# Altium schematic sheet 12V-5V(3.3V).SchDoc
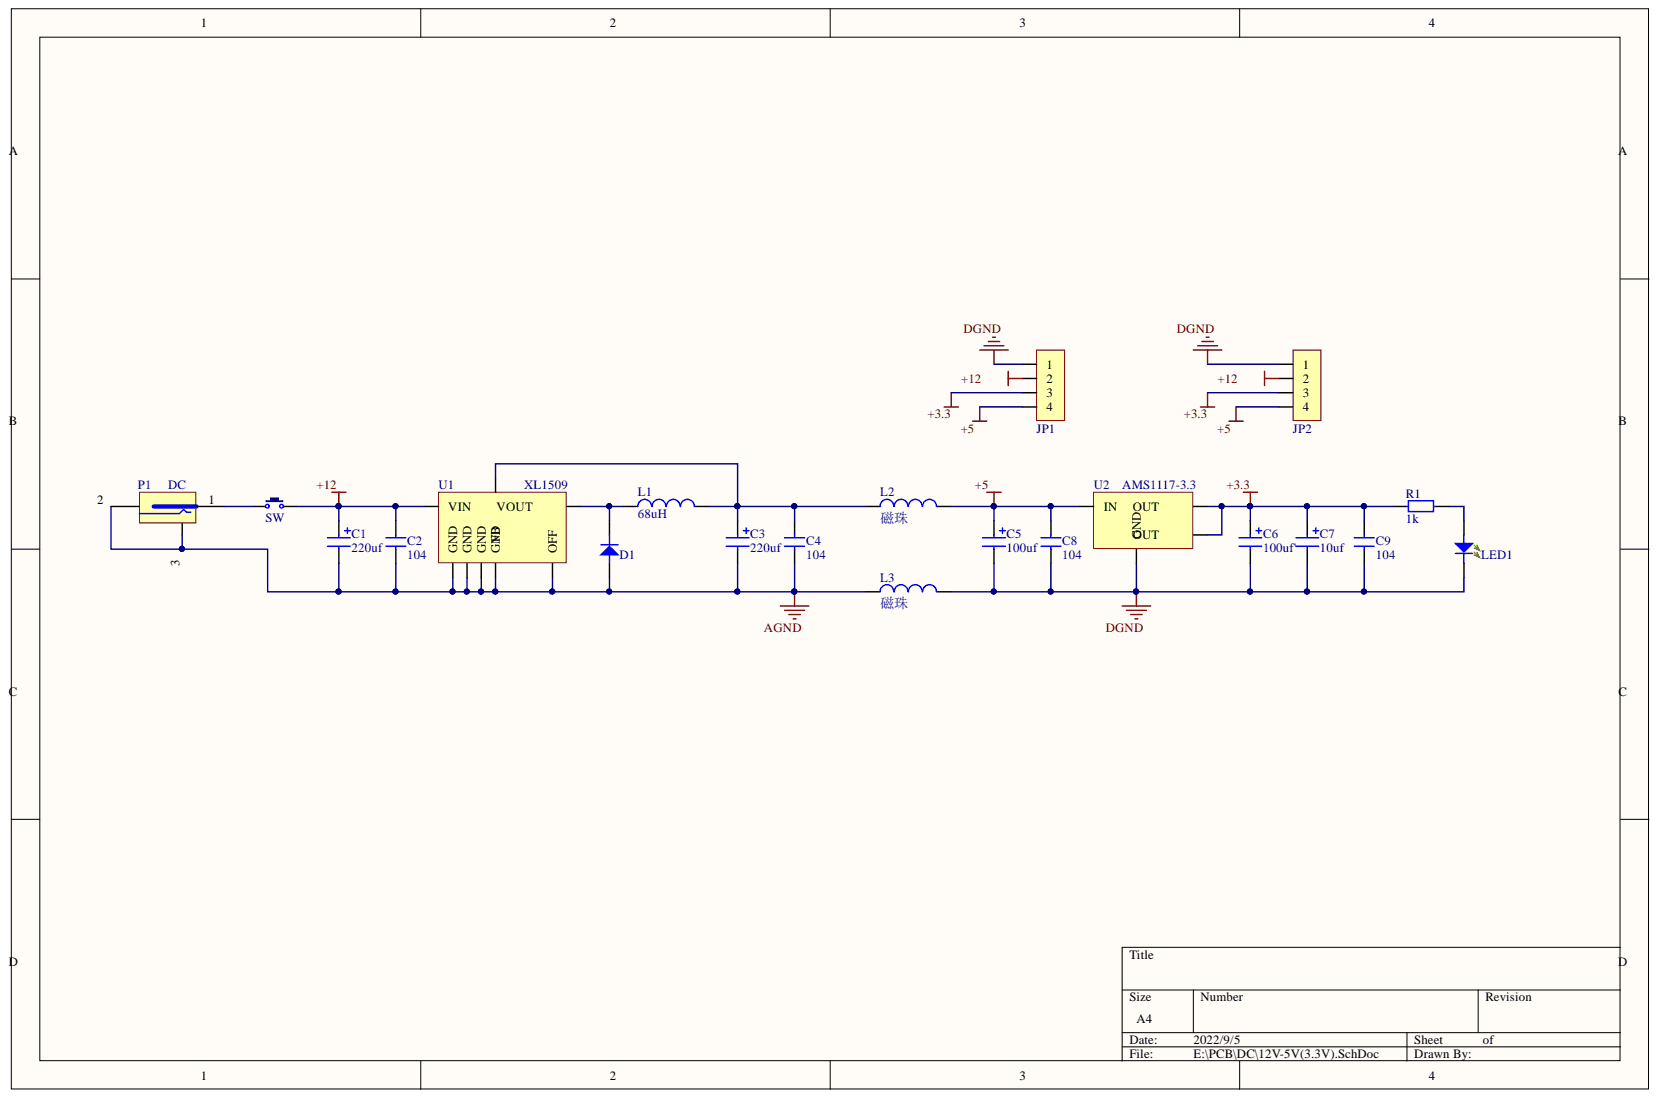
[diagram p0: 12V-5V(3.3V).SchDoc]

## Parts
C1  value 220uf  [CAP 2.0*5*7]  (hidden comment: CAP_ELEC)
C2  value 104  [CC 2.2*5]  (hidden comment: CAP)
C3  value 220uf  [CAP 2.0*5*7]  (hidden comment: CAP_ELEC)
C4  value 104  [CC 2.2*5]  (hidden comment: CAP)
C5  value 100uf  [CAP 2.0*5*7]  (hidden comment: CAP_ELEC)
C6  value 100uf'  [CAP 2.0*5*7]  (hidden comment: CAP_ELEC)
C7  value 10uf  [CAP 2.0*5*7]  (hidden comment: CAP_ELEC)
C8  value 104  [CC 2.2*5]  (hidden comment: CAP)
C9  value 104  [CC 2.2*5]  (hidden comment: CAP)
D1  SS34  [SMA]  (value not drawn)
JP1  HDR-1X4  [XH2.54-LI-4P]  (value not drawn)
JP2  HDR-1X4  [HDR2.54-LI-4P]  (value not drawn)
L1  value 68uH  [CDRH74]  (hidden comment: Inductor)
L2  磁珠  [L1206]
L3  磁珠  [L1206]
LED1  LED  [LED 3MM-G]
P1  DC  [DC-005]
R1  value 1k  [RS 1/4W]  (hidden comment: RES)
SW  BUTTON  [KFC DIP-8X8]  (value not drawn)
U1  XL1509  [SOP8_M]
U2  AMS1117-3.3  [SOT223_M]

## Nets
+3.3: C6.1 C7.1 C9.2 JP1.3 JP2.3 R1.1 U2.2 U2.4
+5: C5.1 C8.2 JP1.4 JP2.4 L2.2 U2.3(IN)
+12: C1.1 C2.2 JP1.2 JP2.2 SW.2 U1.1(VIN)
AGND: C1.2 C2.1 C3.2 C4.1 D1.1 L3.1 P1.2 P1.3 U1.4(OFF) U1.5(GND) U1.6(GND) U1.7(GND) U1.8(GND)
DGND: C5.2 C6.2 C7.2 C8.1 C9.1 JP1.1 JP2.1 L3.2 LED1.2 U2.1(GND)
NetC3_1: C3.1 C4.2 L1.2 L2.1 U1.3
NetD1_2: D1.2 L1.1 U1.2
NetLED1_1: LED1.1 R1.2
NetP1_1: P1.1 SW.1

## Library data of the parts
C1 C3 C5-C7 = C_ELEC: 电解电容; Component Kind: Standard; Library Name: PvtLib.SchLib
C2 C4 C8 C9 = C: 电容; Component Kind: Standard; Library Name: PvtLib.SchLib
D1 = DIODE: 二极管; Component Kind: Standard; Library Name: PvtLib.SchLib
JP1 JP2 = Header 4: 4P接插件; 版权归属: 源创客; BOM名称: 4P接插件; Component Kind: Standard; 学习资源: www.ooocke.com; Library Name: PvtLib.SchLib
L1 = Inductor: Code_IEC: 1005; Code_JEITA: 0402; Component Kind: Standard; Description: Inductor; LatestRevisionDate: 29-May-2009; LatestRevisionNote: IPC-7351 Footprint Added.; PackageDescription: Chip Inductor; PackageReference: 0402-A; Published: 8-Jun-2000; Signal Integrity: Inductor; Simulation: INDUCTOR; Library Name: PvtLib.SchLib; Publisher: Altium Limited
L2 L3 = 磁珠: Inductor; Code_IEC: 1005; Code_JEITA: 0402; Component Kind: Standard; LatestRevisionDate: 29-May-2009; LatestRevisionNote: IPC-7351 Footprint Added.; PackageDescription: Chip Inductor; PackageReference: 0402-A; Published: 8-Jun-2000; Signal Integrity: Inductor; Simulation: INDUCTOR; Library Name: PvtLib.SchLib; Publisher: Altium Limited
LED1 = LED_GREEN: 发光二极管; Component Kind: Standard; Library Name: PvtLib.SchLib
P1 = DC: DC座; Component Kind: Standard; Library Name: PvtLib.SchLib
R1 = R: 电阻; Component Kind: Standard; Library Name: PvtLib.SchLib
SW = BUTTON: 按键; BOM名称: 2脚轻触开关; 版权归属: 源创客; Component Kind: Standard; 学习资源: www.ooocke.com; Library Name: PvtLib.SchLib
U1 = XL1509: 电源(BUCK)IC; Component Kind: Standard; Library Name: PvtLib.SchLib
U2 = AMS1117-3.3: 电源IC->3.3; Component Kind: Standard; Library Name: PvtLib.SchLib
